# Altium schematic sheet Module.SchDoc(Module)
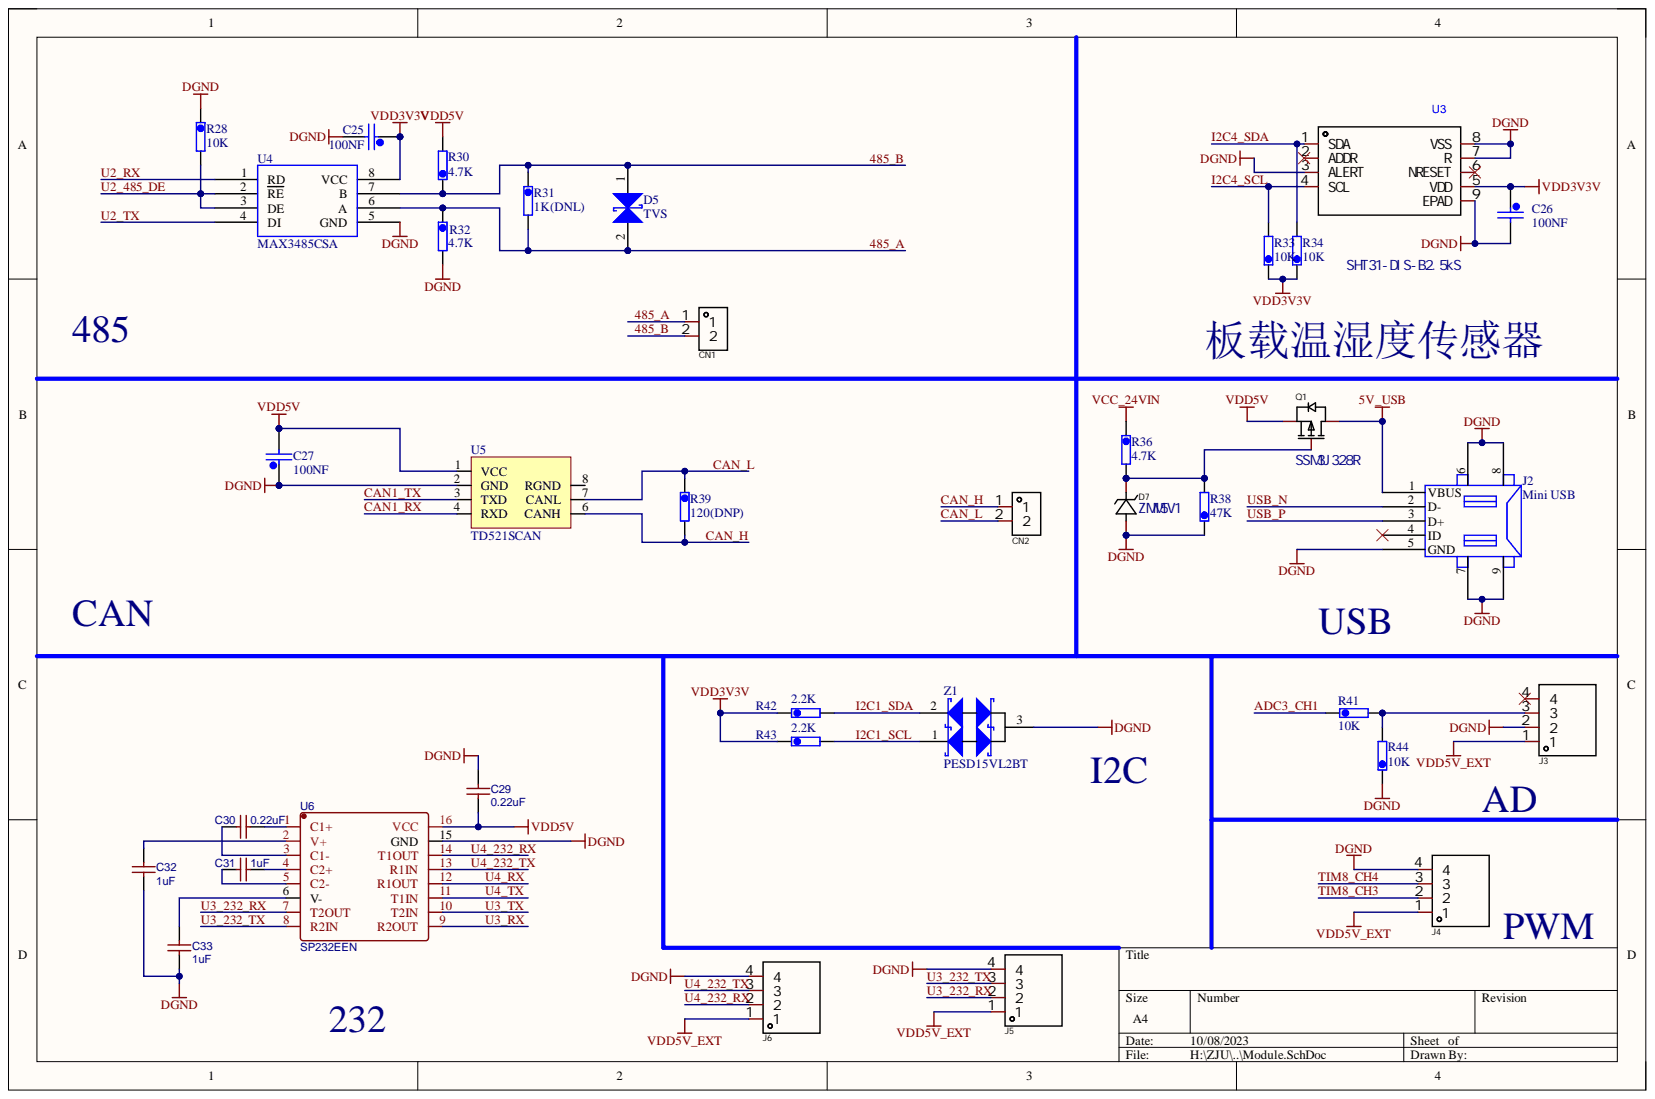
[diagram p0: Module.SchDoc]

## Parts
C25  100NF  [0603C]
C26  100NF  [0402C]
C27  100NF  [0603C]
C29  0.22uF  [0603C]
C30  0.22uF  [0603C]
C31  1uF  [0603C]
C32  1uF  [0603C]
C33  1uF  [0603C]
CN1  HDGC2001WR-2P  [PH-2.0-2P-W]  (value not drawn)
CN2  HDGC2001WR-2P  [PH-2.0-2P-W]  (value not drawn)
D5  TVS  [DO-214AC]
D7  ZMM5V1  [LL-34]
J2  Mini USB  [MICRO-USB-SMD_MICROXNJ]
J3  S4B-PH-K(LF)(SN)  [PH-2.0-4P-W]  (value not drawn)
J4  S4B-PH-K(LF)(SN)  [PH-2.0-4P-W]  (value not drawn)
J5  S4B-PH-K(LF)(SN)  [PH-2.0-4P-W]  (value not drawn)
J6  S4B-PH-K(LF)(SN)  [PH-2.0-4P-W]  (value not drawn)
Q1  SSM3J328R  [SOT-23-3]
R28  10K  [0603R]
R30  4.7K  [0805R]
R31  1K(DNL)  [0603R]
R32  4.7K  [0805R]
R33  10K  [0402]
R34  10K  [0402]
R36  4.7K  [0603R]
R38  47K  [0603R]
R39  120(DNP)  [0603R]
R41  10K  [0402]
R42  2.2K  [0402]
R43  2.2K  [0402]
R44  10K  [0402]
U3  SHT31-DIS-B2.5kS  [DFN-8-SHT31]
U4  MAX3485CSA  [SO-8]
U5  TD521SCAN  [TD5(3)21SCAN]
U6  SP232EEN  [SOP-16]
Z1  PESD15VL2BT  [SOT-23]

## Nets
5V_USB: J2.1(VBUS) Q1.3
DGND: C25.2 C26.2 C27.1 C29.2 C32.1 C33.1 D7.2 J2.5(GND) J2.6 J2.7 J2.8 J2.9 J3.2 J4.4 J5.4 J6.4 R28.1 R32.2 R38.1 R44.1 U3.3(ALERT) U3.7(R) U3.8(VSS) U3.9(EPAD) U4.5(GND) U5.2(GND) U6.15(GND) Z1.3
VCC_24VIN: R36.1
VDD3V3V: C25.1 C26.1 R33.1 R34.1 R42.1 R43.1 U3.5(VDD) U4.8(VCC)
VDD5V: C27.2 C29.1 Q1.2 R30.2 U5.1(VCC) U6.16(VCC)
VDD5V_EXT: J3.1 J4.1 J5.1 J6.1
485_A: CN1.1 D5.2 R31.2 R32.1 U4.6(A)
485_B: CN1.2 D5.1 R30.1 R31.1 U4.7(B)
ADC3_CH1: R41.1
CAN1_RX: U5.4(RXD)
CAN1_TX: U5.3(TXD)
CAN_H: CN2.1 R39.2 U5.6(CANH)
CAN_L: CN2.2 R39.1 U5.7(CANL)
I2C1_SCL: R43.2 Z1.1
I2C1_SDA: R42.2 Z1.2
I2C4_SCL: R33.2 U3.4(SCL)
I2C4_SDA: R34.2 U3.1(SDA)
TIM8_CH3: J4.2
TIM8_CH4: J4.3
U2_485_DE: R28.2 U4.2(RE) U4.3(DE)
U2_RX: U4.1(RD)
U2_TX: U4.4(DI)
U3_232_RX: J5.2 U6.7(T2OUT)
U3_232_TX: J5.3 U6.8(R2IN)
U3_RX: U6.9(R2OUT)
U3_TX: U6.10(T2IN)
U4_232_RX: J6.2 U6.14(T1OUT)
U4_232_TX: J6.3 U6.13(R1IN)
U4_RX: U6.12(R1OUT)
U4_TX: U6.11(T1IN)
USB_N: J2.2(D-)
USB_P: J2.3(D+)
NetC30_1: C30.1 U6.3(C1-)
NetC30_2: C30.2 U6.1(C1+)
NetC31_1: C31.1 U6.5(C2-)
NetC31_2: C31.2 U6.4(C2+)
NetC32_2: C32.2 U6.2(V+)
NetC33_2: C33.2 U6.6(V-)
NetD7_1: D7.1 Q1.1 R36.2 R38.2
NetJ3_3: J3.3 R41.2 R44.2

Not connected: J2 4(ID); J3 4; U3 2(ADDR) 6(NRESET); U5 8(RGND)

## Library data of the parts
C25-C27 = CAP: Capacitors; Component Kind: Standard
  C26: Library Name: ROV_Control.SCHLIB
C29-C33 = ?F: 贴片电容(0603); BOM_Manufacturer: SAMSUNG; BOM_Manufacturer Part: CL10A105KO8NNNC; nameAlias: Capacitance; BOM_JLCPCB Part Class: Extended Part; BOM_Supplier: LCSC; Component Kind: Standard; spicePre: C; spiceSymbolName: CL10A105KO8NNNC; Library Name: 狗-主控板器件原理图.SchLib
CN1 CN2 = HDGC2001WR-2P: PH2弯针2P; Manufacturer: HDGC(华德共创); Add into BOM: yes; Component Kind: Standard; Convert to PCB: yes; Datasheet: https://atta.szlcsc.com/upload/public/pdf/source/20220916/36534CA64A2134C65DEF28B604EC5CCB.pdf; Device: HDGC2001WR-2P; LCSC Part Name: PH2.0弯针 2P; Manufacturer Part: HDGC2001WR-2P; Name: HDGC2001WR-2P; Supplier: LCSC; Supplier Footprint: 弯插,P=2mm; Supplier Part: C5175239; Symbol: HDGC2001WR-2P; Library Name: MainBorad.SCHLIB
D5 = TVS_1: P6KE6.8CA; Component Kind: Standard; Library Name: ROV_Control.SCHLIB
D7 = BZX584C9V1: Manufacturer: YANGJIE(扬杰); Add into BOM: yes; Diode Configuration: 独立式; Power Dissipation: 200mW; Reverse Leakage Current (Ir): 200nA@7V; Zener Impedance (Zzt): 15Ω; Zener Voltage (Nom): 9.1V; Zener Voltage (Range): 8.5V~9.6V; Component Kind: Standard; Convert to PCB: yes; Datasheet: https://atta.szlcsc.com/upload/public/pdf/source/20201222/C968180_8DEF4A0FE776E72B62938E062B6263B6.pdf; Device: BZX584C9V1; JLCPCB Part Class: Extended Part; LCSC Part Name: 9.1V 200mW; Manufacturer Part: BZX584C9V1; Name: BZX584C9V1; PCB3D: ESC_L1.2-W0.8-LS1.6-RD; Supplier: LCSC; Supplier Footprint: SOD-523; Supplier Part: C968211; Symbol: BZX584C9V1; Tolerance: -
J2 = Mini-B USB H: Mini A型USB母座; Component Kind: Standard; Library Name: ROV_Control.SCHLIB
J3-J6 = S4B-PH-K(LF)(SN): ph2弯插4p; Manufacturer: JST; Add into BOM: yes; Number of PINs Per Row: 4; Pins Structure: 1x4P; Pitch: 2mm; Reference Series: PH; Component Kind: Standard; Contact Material: 黄铜; Convert to PCB: yes; Datasheet: https://atta.szlcsc.com/upload/public/pdf/source/20180829/C265452_92616666173A3FAF5DFA68F980034548.pdf; Device: S4B-PH-K(LF)(SN); JLCPCB Part Class: Extended Part; LCSC Part Name: S4B-PH-K(LF)(SN); Manufacturer Part: S4B-PH-K(LF)(SN); Mounting Style: -; Name: S4B-PH-K(LF)(SN); Number of Rows: 1; PCB3D: CONN-TH_S4B-PH-K-LF-SN; Row Spacing: -; Supplier: LCSC; Supplier Footprint: 插件,P=2mm; Supplier Part: C265452; Symbol: S4B-PH-K(LF)(SN); Library Name: MainBorad.SCHLIB
Q1 = AO3401A_C347476: Manufacturer: UMW(广东友台半导体); Add into BOM: yes; Component Kind: Standard; Convert to PCB: yes; Datasheet: https://atta.szlcsc.com/upload/public/pdf/source/20190827/C347476_6CCC01FEC3E3D166C83BA0E415FD2056.pdf; Device: AO3401A_C347476; JLCPCB Part Class: Extended Part; LCSC Part Name: AO3401A; Manufacturer Part: AO3401A; Name: AO3401A; PCB3D: SOT-23-3P_L2.9-W1.3-H1.0-LS2.4-P0.95; Supplier: LCSC; Supplier Footprint: SOT-23; Supplier Part: C347476; Symbol: AO3401A_C347476
R28 R30-R32 R36 R38 R39 = RESA: Resistor; Component Kind: Standard
R33 R34 R42 R43 = RESA: Resistance; Component Kind: Standard
R41 R44 = RESA_3: Resistor; Component Kind: Standard; Library Name: ROV.SCHLIB
U3 = SHT31-DIS-B2.5kS: Manufacturer: Sensirion(瑞士盛思锐); Add into BOM: yes; Component Kind: Standard; Convert to PCB: yes; Datasheet: https://atta.szlcsc.com/upload/public/pdf/source/20230202/324039AB95BA3D329FC29BEE4FBFDB91.pdf; Device: SHT31-DIS-B2.5kS; JLCPCB Part Class: Extended Part; LCSC Part Name: 1-101386-01; Manufacturer Part: SHT31-DIS-B2.5kS; Name: SHT31-DIS-B2.5kS; PCB3D: DFN-8_L2.5-W2.5-H1.0-P0.50; Supplier: LCSC; Supplier Footprint: DFN-8-EP(2.5x2.5); Supplier Part: C80862; Symbol: SHT31-DIS-B
U4 = MAX485: RS-485 transceiver; Component Kind: Standard
U5 = TD5(3)21SCAN: Component Kind: Standard; Library Name: Pixshark.SCHLIB
U6 = SP232EEN_[C2904740]: 串口232芯片; BOM_Manufacturer: UMW(友台半导体); Contributor: LCSC; BOM_Manufacturer Part: SP232EEN; BOM_Supplier: LCSC; BOM_Supplier Part: C2904740; Component Kind: Standard; ComponentLink1Description: 供应商链接; ComponentLink1URL: https://item.szlcsc.com/3170779.html; spicePre: U; spiceSymbolName: SP232EEN; Library Name: 狗-主控板器件原理图.SchLib
Z1 = PESD1CAN: 过压保护; Component Kind: Standard; Library Name: Fszhang.IntLib
